# Altium schematic sheet FPGA_MISC.SchDoc(FPGA_MISC)
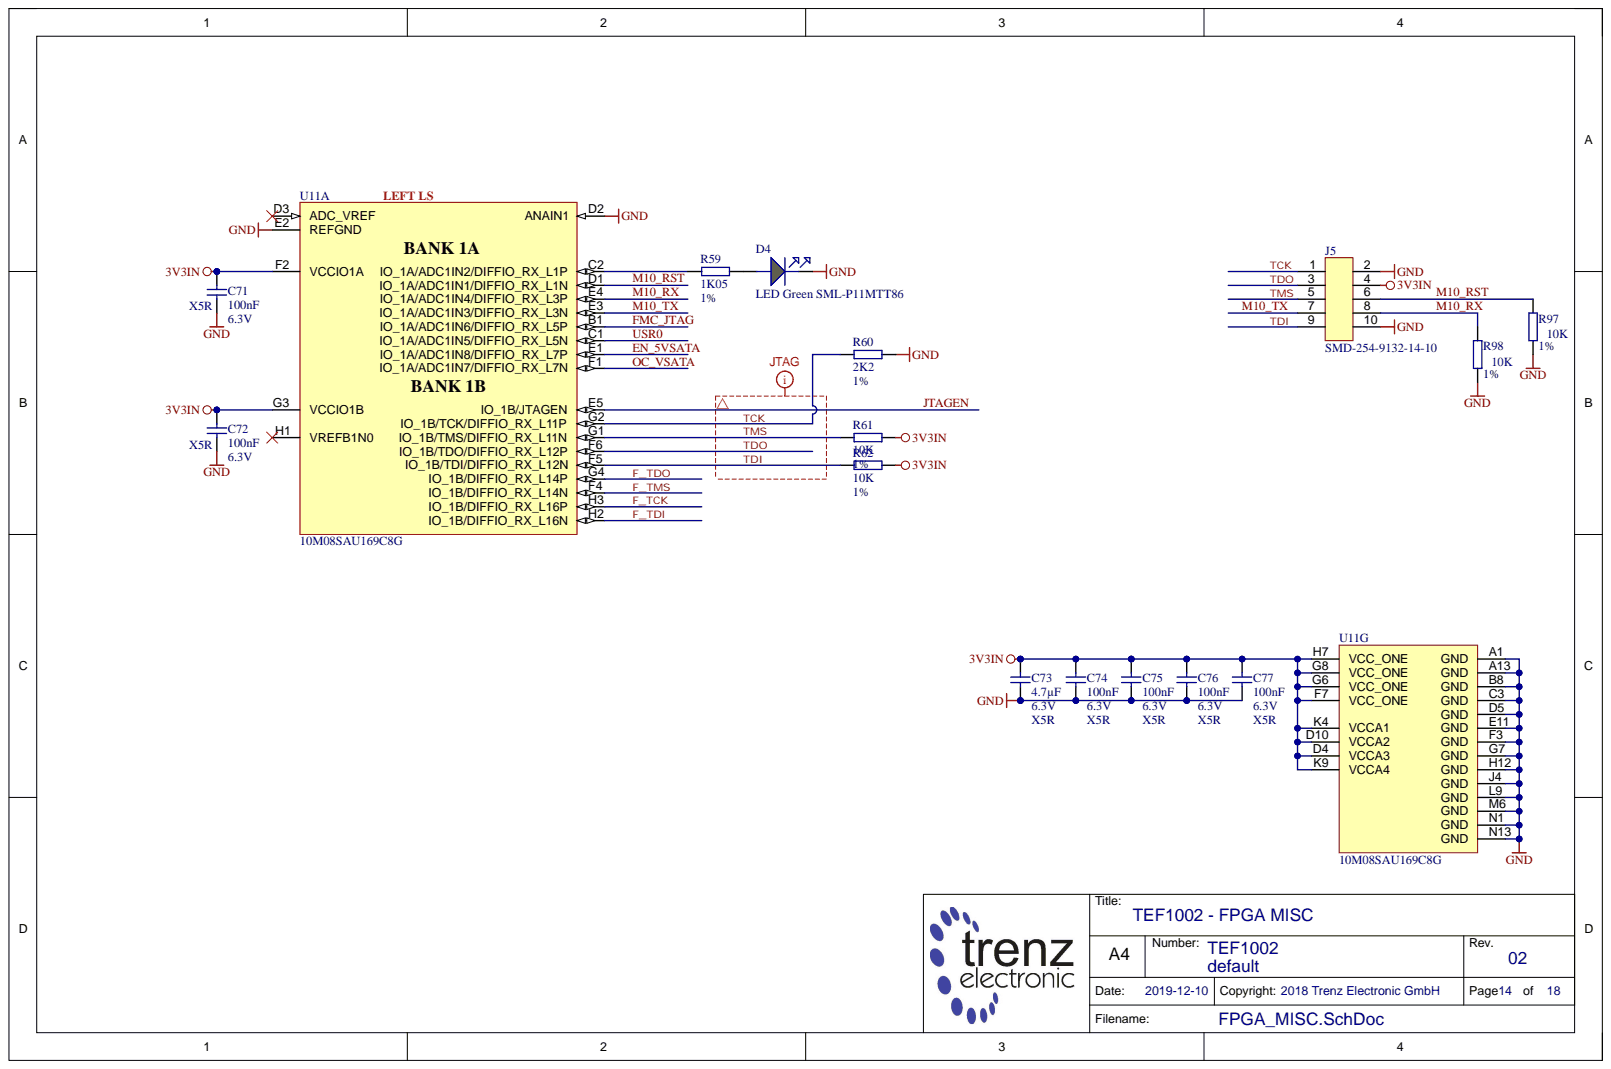
[diagram p0: FPGA_MISC.SchDoc]

## Parts
C71  100nF  Technology: X5R  Voltage: 6.3V  [CAPC0603X33N]
C72  100nF  Technology: X5R  Voltage: 6.3V  [CAPC0603X33N]
C73  4.7µF  Technology: X5R  Voltage: 6.3V  [CAPC1005X60N]
C74  100nF  Technology: X5R  Voltage: 6.3V  [CAPC0603X33N]
C75  100nF  Technology: X5R  Voltage: 6.3V  [CAPC0603X33N]
C76  100nF  Technology: X5R  Voltage: 6.3V  [CAPC0603X33N]
C77  100nF  Technology: X5R  Voltage: 6.3V  [CAPC0603X33N]
D4  LED Green SML-P11MTT86  Database Table Name: LED  H-ART: SML-P11MTT86  [LEDC1006X25N_GREEN]
J5  SMD-254-9132-14-10  [SMD-254-9132-14-10]
R59  1K05  Tolerance: 1%  [RESC1005X40N]
R60  2K2  Tolerance: 1%  [RESC1005X40N]
R61  10K  [RESC1005X40N]
R62  10K  Tolerance: 1%  [RESC1005X40N]
R97  10K  Tolerance: 1%  [RESC1005X40N]
R98  10K  Tolerance: 1%  [RESC1005X40N]
U11  10M08SAU169C8G  [BGA169C80P13X13_11X11X15]  (parts A,G)

## Nets
3V3IN: C71.1 C72.1 C73.1 C74.1 C75.1 C76.1 C77.1 J5.4 R61.1 R62.1 U11.D4(VCCA3) U11.D10(VCCA2) U11.F2(VCCIO1A) U11.F7(VCC_ONE) U11.G3(VCCIO1B) U11.G6(VCC_ONE) U11.G8(VCC_ONE) U11.H7(VCC_ONE) U11.K4(VCCA1) U11.K9(VCCA4)
GND: C71.2 C72.2 C73.2 C74.2 C75.2 C76.2 C77.2 D4.K J5.2 J5.10 R60.2 R97.2 R98.2 U11.A1(GND) U11.A13(GND) U11.B8(GND) U11.C3(GND) U11.D2(ANAIN1) U11.D5(GND) U11.E2(REFGND) U11.E11(GND) U11.F3(GND) U11.G7(GND) U11.H12(GND) U11.J4(GND) U11.L9(GND) U11.M6(GND) U11.N1(GND) U11.N13(GND)
EN_5VSATA: U11.E1(IO_1A/ADC1IN8/DIFFIO_RX_L7P)
F_TCK: U11.H3(IO_1B/DIFFIO_RX_L16P)
F_TDI: U11.H2(IO_1B/DIFFIO_RX_L16N)
F_TDO: U11.G4(IO_1B/DIFFIO_RX_L14P)
F_TMS: U11.F4(IO_1B/DIFFIO_RX_L14N)
FMC_JTAG: U11.B1(IO_1A/ADC1IN6/DIFFIO_RX_L5P)
JTAGEN: U11.E5(IO_1B/JTAGEN)
M10_RST: J5.6 R97.1 U11.D1(IO_1A/ADC1IN1/DIFFIO_RX_L1N)
M10_RX: J5.8 R98.1 U11.E4(IO_1A/ADC1IN4/DIFFIO_RX_L3P)
M10_TX: J5.7 U11.E3(IO_1A/ADC1IN3/DIFFIO_RX_L3N)
OC_VSATA: U11.F1(IO_1A/ADC1IN7/DIFFIO_RX_L7N)
TCK: J5.1 R60.1 U11.G2(IO_1B/TCK/DIFFIO_RX_L11P)
TDI: J5.9 R62.2 U11.F5(IO_1B/TDI/DIFFIO_RX_L12N)
TDO: J5.3 U11.F6(IO_1B/TDO/DIFFIO_RX_L12P)
TMS: J5.5 R61.2 U11.G1(IO_1B/TMS/DIFFIO_RX_L11N)
USR0: U11.C1(IO_1A/ADC1IN5/DIFFIO_RX_L5N)
NetD4_A: D4.A R59.2
NetR59_1: R59.1 U11.C2(IO_1A/ADC1IN2/DIFFIO_RX_L1P)

Not connected: U11 D3(ADC_VREF) H1(VREFB1N0)

## Library data of the parts
C71 C72 C74-C77 = 24915: KON 100nF 6.3V X5R 10% SMD BF 0603 (0201); Database Table Name: C_0603_0201; Mixed: SMT; Preferred: Yes; Temperature-Range: -55/+85; Value: 100n; AN: 24915; Case: 0603 (0201); Component Kind: Standard; Library Name: TE.DbLib; Tolerance: 10%
C73 = 24719: KON 4.7µF 6.3V X5R 20% SMD BF 1005 (0402); Database Table Name: C_1005_0402; Mixed: SMT; Preferred: Yes; Temperature-Range: -55/+85; Value: 4.7u; AN: 24719; Case: 1005 (0402); Component Kind: Standard; Library Name: TE.DbLib; Tolerance: 20%
D4 = 24211: LED Grün SML-P11MTT86 SMD; Mixed: SMT; Preferred: Yes; Temperature-Range: -40/+85; AN: 24211; Case: 1005 (0402); Component Kind: Standard; Library Name: TE.DbLib; HelpURL: B:\AD\adlib\datasheet\SML-P1
J5 = 22741: Stiftleiste gerade 2-reihig, 10pol. SMD; Database Table Name: CON_HEADER; H-ART: 9132-14-10-00-0-ST; Mixed: HAND; Trenz-Stock: Yes; AN: 22741; Component Kind: Standard; Library Name: TE.DbLib; HelpURL: B:\AD\adlib\datasheet\9532_9132
R59 = 25956: WID SMD 1K05 1% 0,063W  BF 1005 (0402); Database Table Name: R_1005_0402; Mixed: SMT; Value: 1.05K; AN: 25956; Case: 1005 (0402); Component Kind: Standard; Library Name: TE.DbLib; Technology: TK100
R60 = 22748: WID SMD 2K2 1% 0,063W BF 1005 (0402); Database Table Name: R_1005_0402; H-ART: RC0402FR-132K2L; Mixed: SMT; Preferred: Yes; Value: 2.2K; AN: 22748; Case: 1005 (0402); Component Kind: Standard; Library Name: TE.DbLib; Technology: TK100
R61 R62 R97 R98 = 22750: WID SMD 10K 1% 0,063W BF 1005 (0402); Database Table Name: R_1005_0402; H-ART: RC0402FR-0710KL; Mixed: SMT; Preferred: Yes; AN: 22750; Case: 1005 (0402); Component Kind: Standard; Library Name: TE.DbLib; Technology: TK100; Value: 10K
  R61: Tolerance: 1%
U11 = 27930: Database Table Name: PROG_LOGIC; Mixed: SMT; Temperature-Range: 0/+85 (Tj); AN: 27930; Case: LFBGA169; Component Kind: Standard; Description: 10M08SAU169C8G; H-ART: 10M08SAU169C8G; Library Name: TE.DbLib; Storage-Temperature: -65/+150(Abs); HelpURL: b:\AD\adlib\datasheet\ALTERA_MAX10\
